# Altium schematic sheet Schematic.SchDoc
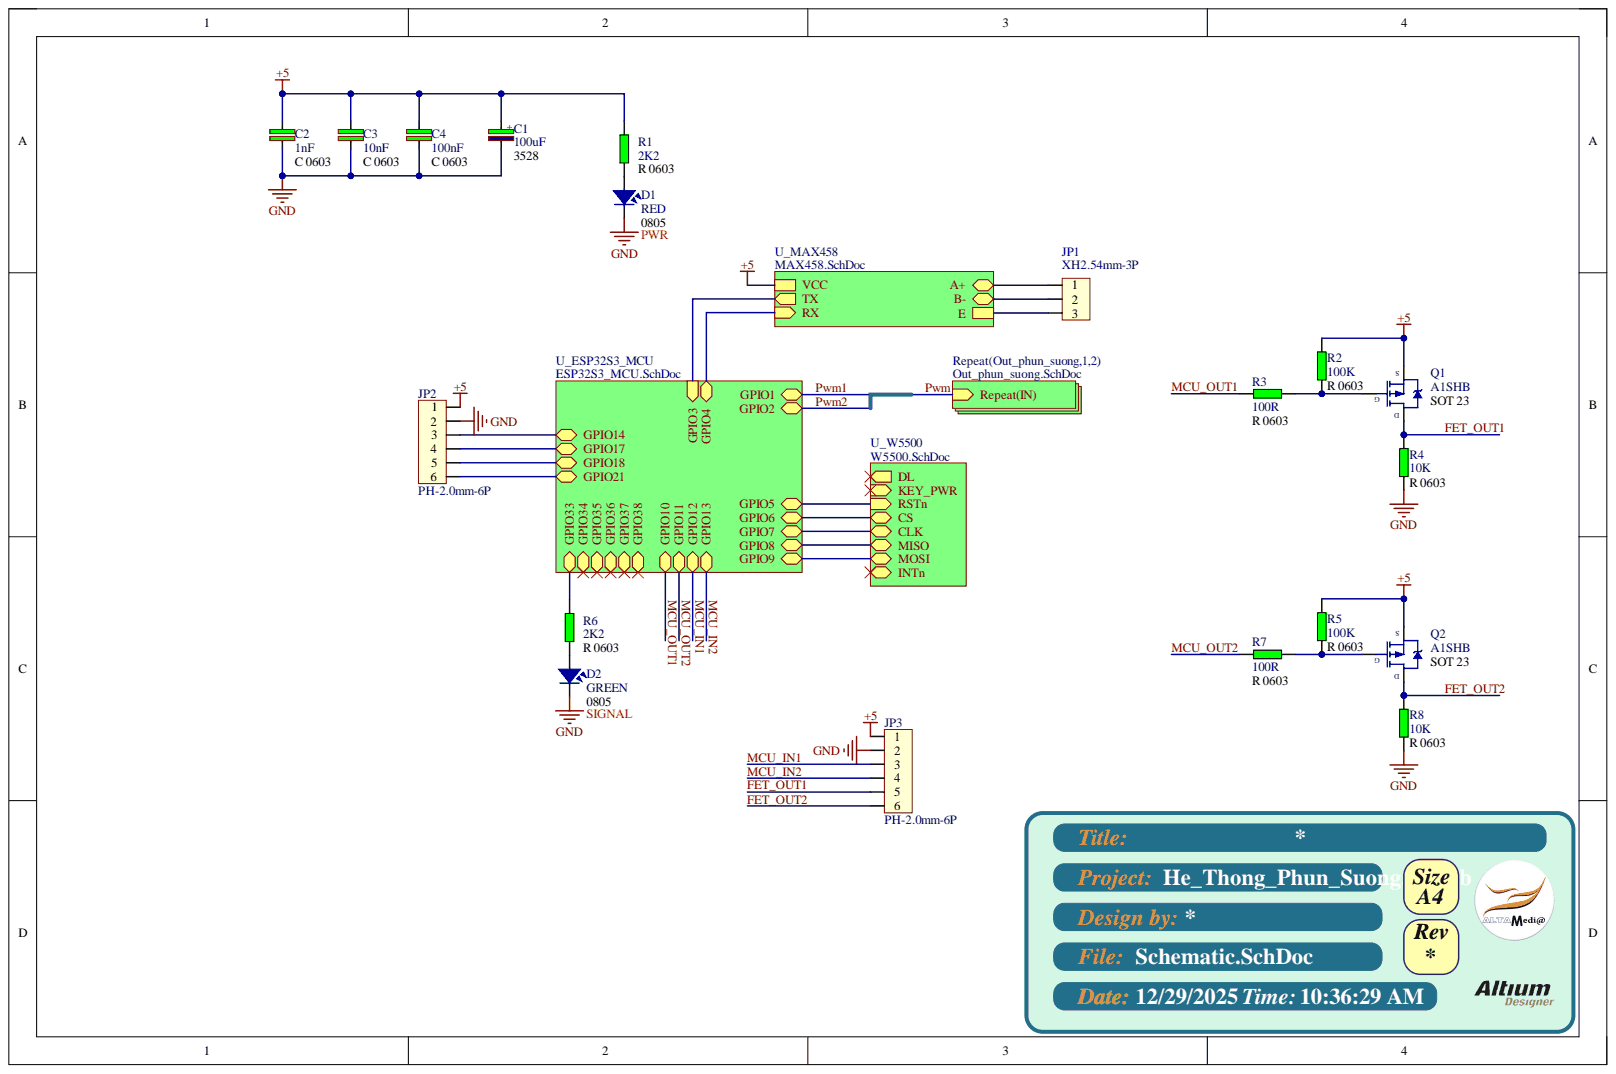
[diagram p0: Schematic.SchDoc]

## Parts
C1  100uF  Size: 3528  [SMD 3528]
C2  1nF  [C-0603]
C3  10nF  Size: C 0603  [C-0603]
C4  100nF  Size: C 0603  [C-0603]
D1  RED  FNC: PWR  Size: 0805  [LED-0805-Blue]
D2  GREEN  FNC: SIGNAL  Size: 0805  [LED-0805-Blue]
JP1  XH2.54mm-3P  [CON_3P_R]
JP2  PH-2.0mm-6P  [JST_B6B-PH-SM4-TB(LF)(SN)]
JP3  PH-2.0mm-6P  [JST_B6B-PH-SM4-TB(LF)(SN)]
Q1  A1SHB  [SOT23A]
Q2  A1SHB  [SOT23A]
R1  2K2  [R-0603]
R2  100K  [R-0603]
R3  100R  Size: R 0603  [R-0603]
R4  10K  Size: R 0603  [R-0603]
R5  100K  [R-0603]
R6  2K2  [R-0603]
R7  100R  Size: R 0603  [R-0603]
R8  10K  Size: R 0603  [R-0603]

## Nets
+5: C1.1 C2.1 C3.1 C4.1 JP2.1 JP3.1 Q1.3 Q2.3 R1.1 R2.2 R5.2
GND: C1.2 C2.2 C3.2 C4.2 D1.2 D2.2 JP2.2 JP3.2 R4.1 R8.1
FET_OUT1: JP3.5 Q1.2 R4.2
FET_OUT2: JP3.6 Q2.2 R8.2
MCU_IN1: JP3.3
MCU_IN2: JP3.4
MCU_OUT1: R3.2
MCU_OUT2: R7.2
NetD1_1: D1.1 R1.2
NetD2_1: D2.1 R6.2
NetJP1_1: JP1.1
NetJP1_2: JP1.2
NetJP1_3: JP1.3
NetJP2_3: JP2.3
NetJP2_4: JP2.4
NetJP2_5: JP2.5
NetJP2_6: JP2.6
NetQ1_1: Q1.1 R2.1 R3.1
NetQ2_1: Q2.1 R5.1 R7.1
NetR6_1: R6.1
CLK:
CS:
DL:
GPIO3:
GPIO4:
GPIO5:
GPIO8:
GPIO9:
GPIO34:
GPIO35:
GPIO36:
GPIO37:
GPIO38:
INTn:
KEY_PWR:
Pwm1:
Pwm2:

## Library data of the parts
C1 = CP0: Cap P  0,1uF < 22uF , 16 - 50V; Description 2: 0,1uF => 33uF/50V - 10uF => 22uF/63V; Description 3: 0,47uF => 10uF/100V; Value: 100uF/16V; Component Kind: Standard; Library Name: My Library.SchLib
C2-C4 = CN5: Component Kind: Standard; Library Name: My Library.SchLib
  C2: Size: C 0603; Value: 1nF
  C3: Value: 10nF
  C4: Value: 100nF
D1 D2 = LED: LED Single colour Green and Red; Component Kind: Standard; Library Name: My Library.SchLib
  D1: Value: RED
  D2: Value: GREEN
JP1 = Con3: Connector 3; Component Kind: Standard; Library Name: My Library.SchLib; Value: Connector 3
JP2 JP3 = Con6: Connector 6; Component Kind: Standard; Library Name: My Library.SchLib; Value: Connector 6
Q1 Q2 = IRF9540: HEXFET P-Channel Power MOSFET; Component Kind: Standard; Library Name: My Library.SchLib; Simulation: IRF9540; Size: SOT 23; Value: A1SHB
R1 R6 = RES: Resistor 1/4W; Component Kind: Standard; Library Name: My Library.SchLib; Size: R 0603; Value: 2K2
R2 R5 = RES: SAISO: 5%; Size2: R 0805; Component Kind: Standard; Library Name: Schlib.SchLib; Size: R 0603; Value: 100K
R3 R4 R7 R8 = RES: Component Kind: Standard; Library Name: My Library.SchLib
  R3 R7: Value: 100R
  R4 R8: Value: 10K
